# Altium schematic sheet [04] - USBtoUART.SchDoc
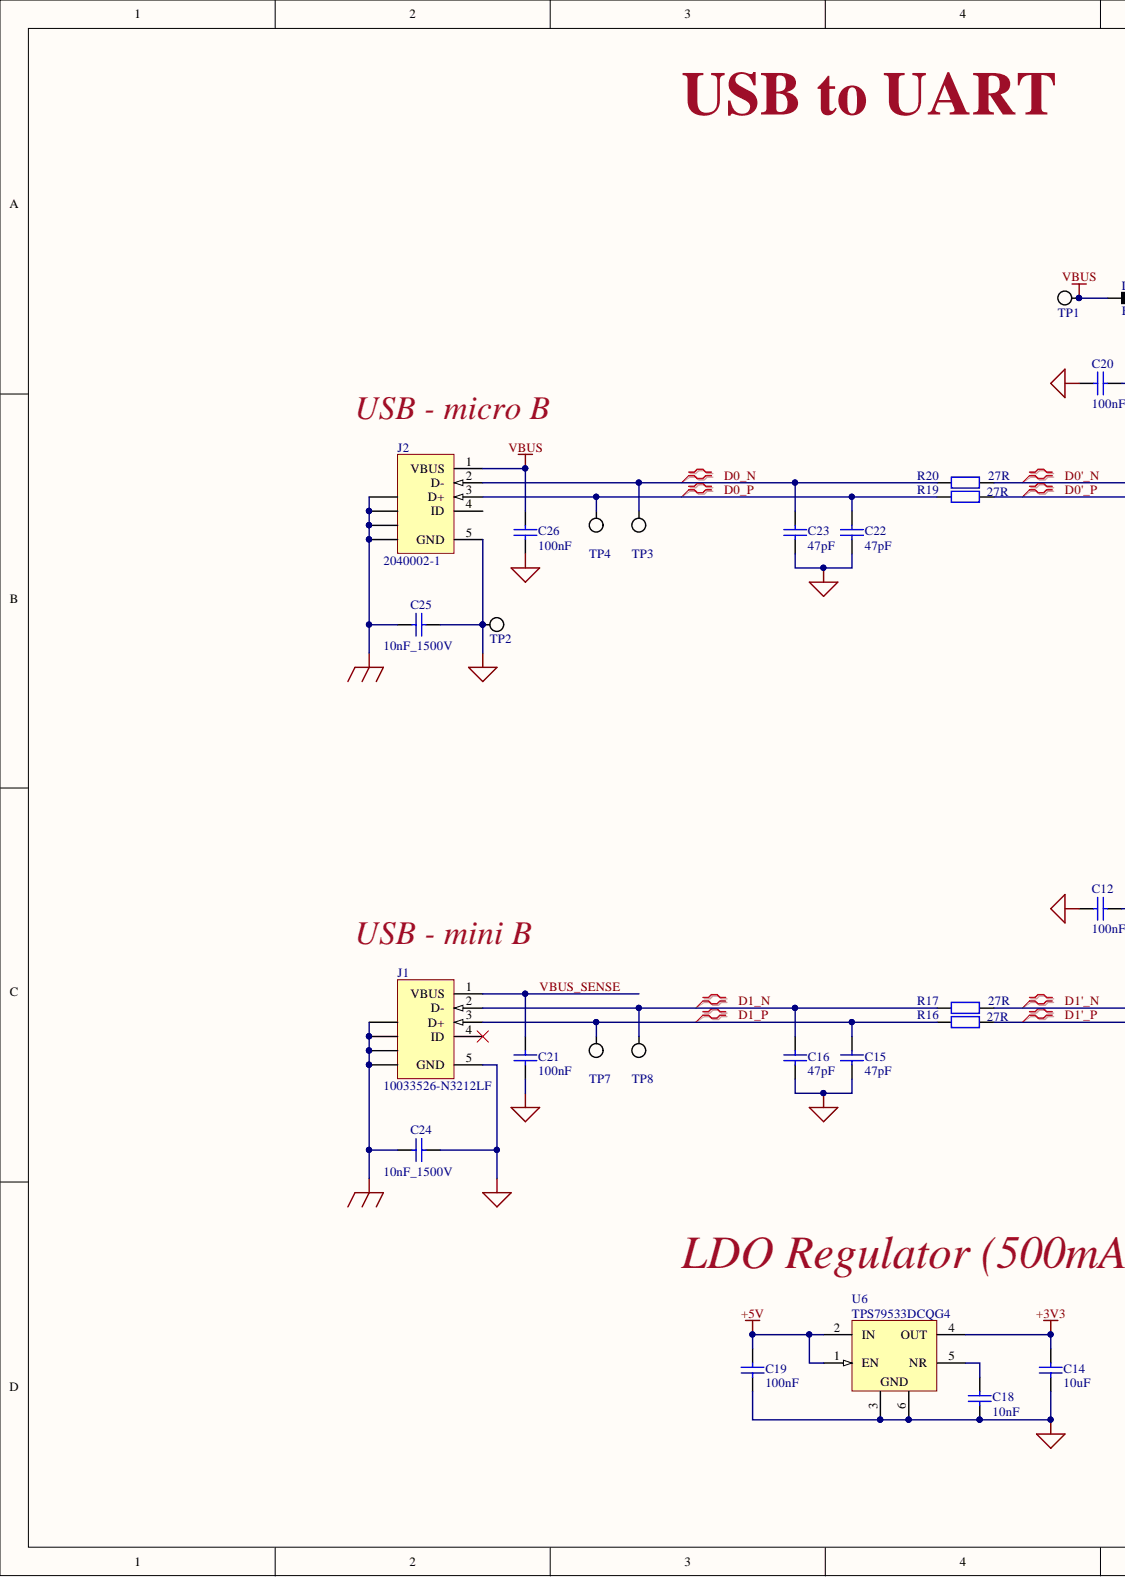
[diagram p0: [04] - USBtoUART.SchDoc, left]
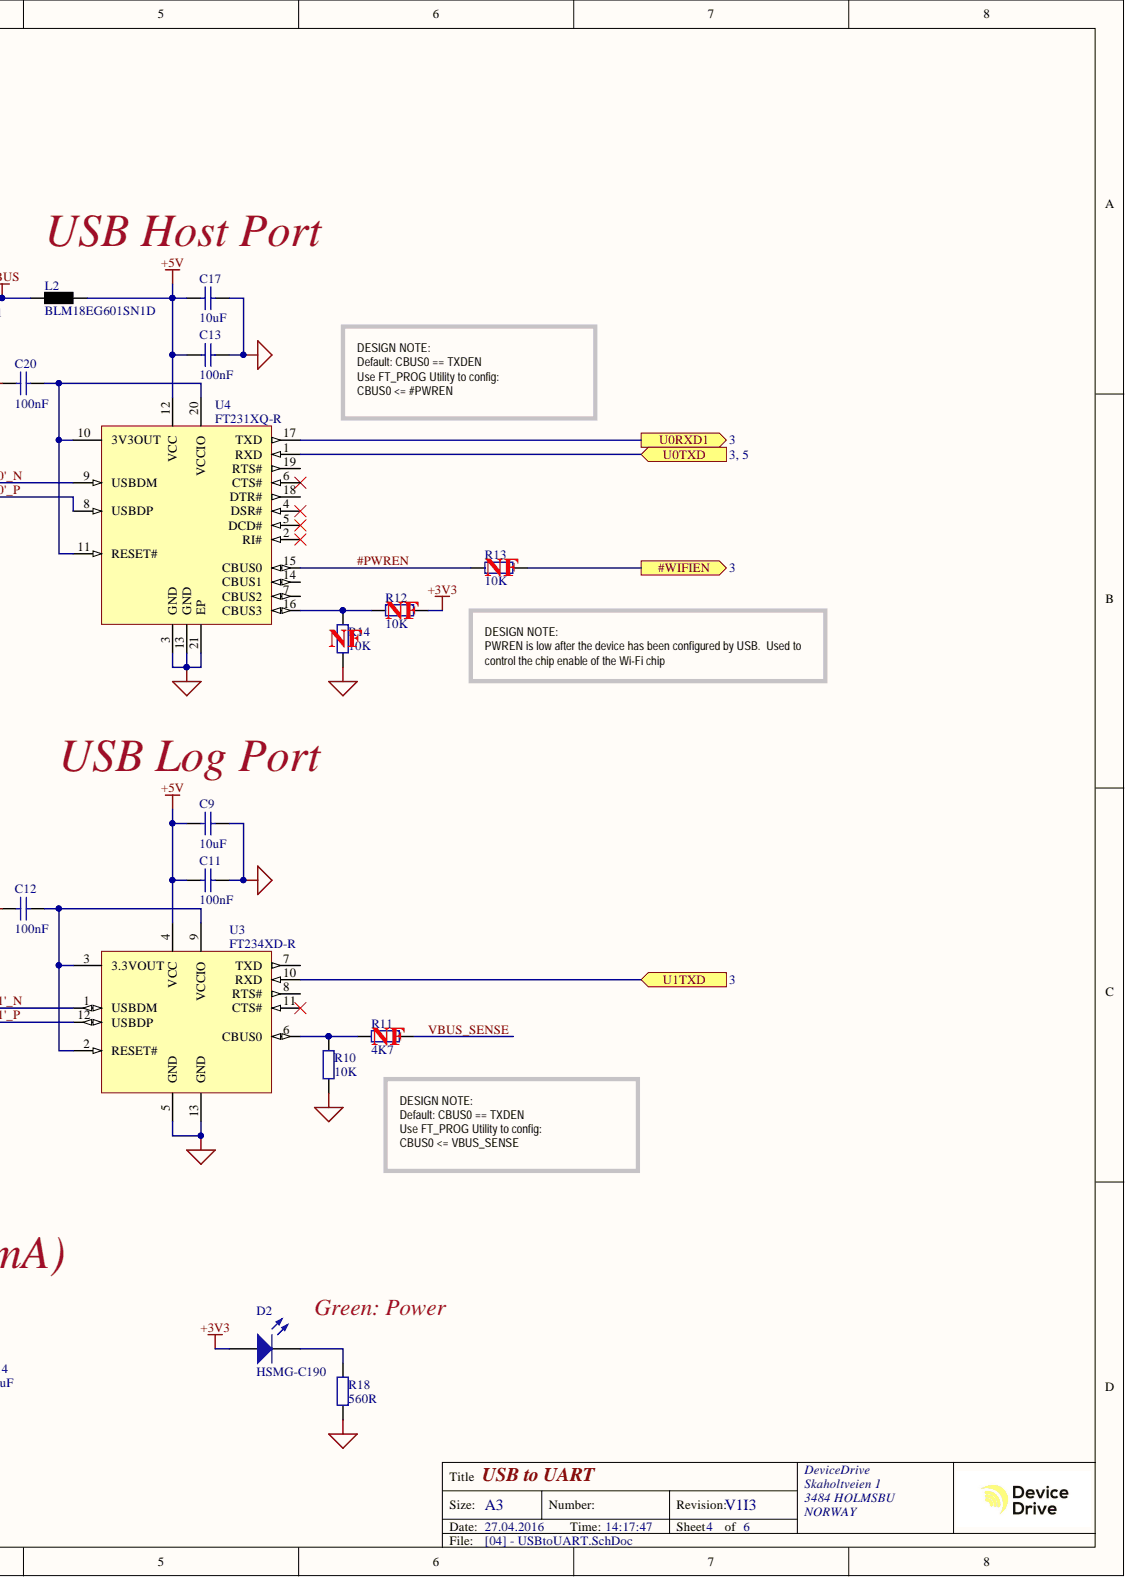
[diagram p1: [04] - USBtoUART.SchDoc, right]

## Parts
C9  10uF  [C0603]
C11  100nF  [C0402]
C12  100nF  [C0402]
C13  100nF  [C0402]
C14  10uF  [C0603]
C15  47pF  [C0402]
C16  47pF  [C0402]
C17  10uF  [C0603]
C18  10nF  [C0402]
C19  100nF  [C0402]
C20  100nF  [C0402]
C21  100nF  [C0402]
C22  47pF  [C0402]
C23  47pF  [C0402]
C24  10nF_1500V  [C1206]
C25  10nF_1500V  [C1206]
C26  100nF  [C0402]
D2  HSMG-C190  [LED_0603_GREEN]
J1  10033526-N3212LF  Connector Type: USB - mini B  [440479]
J2  2040002-1  [MICRO_USB_1]
L2  BLM18EG601SN1D  [R0603]
R10  10K  [R0402]
R11  4K7  [R0402]
R12  10K  [R0402]
R13  10K  [R0402]
R14  10K  [R0402]
R16  27R  [R0402]
R17  27R  [R0402]
R18  560R  [R0402]
R19  27R  [R0402]
R20  27R  [R0402]
TP1  Testpoint_1.5mm  [TESTPOINT_1.5MM]  (value not drawn)  (no BOM)
TP2  Testpoint_1.5mm  [TESTPOINT_1.5MM]  (value not drawn)  (no BOM)
TP3  Testpoint_1.5mm  [TESTPOINT_1.5MM]  (value not drawn)  (no BOM)
TP4  Testpoint_1.5mm  [TESTPOINT_1.5MM]  (value not drawn)  (no BOM)
TP7  Testpoint_1.5mm  [TESTPOINT_1.5MM]  (value not drawn)  (no BOM)
TP8  Testpoint_1.5mm  [TESTPOINT_1.5MM]  (value not drawn)  (no BOM)
U3  FT234XD-R  [DFN-12_V]
U4  FT231XQ-R  [QFN-20_N]
U6  TPS79533DCQG4  [TI-DCQ6_N]

## Ports
#WIFIEN, U0RXD1, U0TXD, U1TXD

## Nets
+3V3: C14.2 D2.2 R12.1 U6.4(OUT)
+5V: C9.2 C11.2 C13.2 C17.2 C19.2 L2.2 U3.4(VCC) U4.12(VCC) U6.1(EN) U6.2(IN)
EGND: C24.2 C25.2 J1.6 J1.7 J1.8 J1.9 J2.6 J2.7 J2.8 J2.9
GND: C9.1 C11.1 C12.2 C13.1 C14.1 C15.1 C16.1 C17.1 C18.1 C19.1 C20.2 C21.1 C22.1 C23.1 C24.1 C25.1 C26.1 J1.5(GND) J2.5(GND) R10.1 R14.2 R18.1 TP2.1 U3.5(GND) U3.13(GND) U4.3(GND) U4.13(GND) U4.21(EP) U6.3 U6.6
VBUS: C26.2 J2.1(VBUS) L2.1 TP1.1
#PWREN: R13.2 U4.15(CBUS0)
#WIFIEN: R13.1 ; port #WIFIEN
D0'_N: R20.2 U4.9(USBDM)
D0'_P: R19.2 U4.8(USBDP)
D0_N: C23.2 J2.2(D-) R20.1 TP3.1
D0_P: C22.2 J2.3(D+) R19.1 TP4.1
D1'_N: R17.2 U3.1(USBDM)
D1'_P: R16.2 U3.12(USBDP)
D1_N: C16.2 J1.2(D-) R17.1 TP8.1
D1_P: C15.2 J1.3(D+) R16.1 TP7.1
U0RXD1: U4.17(TXD) ; port U0RXD1
U0TXD: U4.1(RXD) ; port U0TXD
U1TXD: U3.10(RXD) ; port U1TXD
VBUS_SENSE: C21.2 J1.1(VBUS) R11.1
NetC12_1: C12.1 U3.2(RESET#) U3.3(3.3VOUT) U3.9(VCCIO)
NetC18_2: C18.2 U6.5(NR)
NetC20_1: C20.1 U4.10(3V3OUT) U4.11(RESET#) U4.20(VCCIO)
NetD2_1: D2.1 R18.2
NetR10_2: R10.2 R11.2 U3.6(CBUS0)
NetR12_2: R12.2 R14.1 U4.16(CBUS3)

Not connected: J1 4(ID); J2 4(ID); U3 7(TXD) 8(RTS#) 11(CTS#); U4 2(RI#) 4(DSR#) 5(DCD#) 6(CTS#) 7(CBUS2) 14(CBUS1) 18(DTR#) 19(RTS#)

## Library data of the parts
C9 C11-C13 C15-C17 C20-C26 = Cap: Capacitor; Value: 100pF; Component Kind: Standard; LatestRevisionDate: 17-Jul-2002; LatestRevisionNote: Re-released for DXP Platform.; Library Name: Miscellaneous Devices.IntLib; PackageDescription: Radial Cap, Thru-Hole; 2 Leads; 0.3 in Pin Spacing; PackageReference: RAD-0.3; Published: 8-Jun-2000; Signal Integrity: Cap; Simulation: CAP; Publisher: Altium Limited
C14 C18 C19 = Cap Semi: Capacitor (Semiconductor SIM Model); Value: 1nF; Component Kind: Standard; LatestRevisionDate: 17-Jul-2002; LatestRevisionNote: Re-released for DXP Platform.; PackageReference: C1206; Published: 8-Jun-2000; Signal Integrity: Cap Semi; Simulation: CAP; Library Name: PPSPowerManagement.SCHLIB; Publisher: Altium Limited
D2 = HSMG-C190: Surface Mount Chip LED, Green; LuminousIntensity: 4mcd (Minimum).; PCB_Layout: Complies with manufacturer's recommendation.; Component Kind: Standard; ComponentLink1Description: Manufacturer Link; ComponentLink1URL: http://www.agilent.com/; ComponentLink2Description: Datasheet; ComponentLink2URL: http://cp.literature.agilent.com/litweb/pdf/5988-6271EN.pdf; DatasheetDocument: 30-Apr-2002; LED_Colour: Green; Library Name: Agilent Optoelectronic LED.IntLib; PackageDocument: 11-Mar-2002; PackageReference: 1.6X0.8X0.8; Published: 11-Dec-2002; Signal Integrity: LED; Simulation: Agl_HSMGC110; Publisher: Altium Limited
J1 = 440479-1: CONN MINI USB RCPT RA TYPE B SMD; Manufacturer: FCI; Gender: Receptacle; Number of Contacts: 5; Category: Connectors, Interconnects; Component Kind: Standard; ComponentLink1Description: http://portal.fciconnect.com/Comergent//fci/drawing/10033526.pdf; ComponentLink1URL: http://portal.fciconnect.com/Comergent//fci/drawing/10033526.pdf; Features: Board Guide, Solder Retention; Ingress Protection: -; Library Name: AMP Serial Bus USB.IntLib; Manufacturer Part Number: 10033526-N3212LF; Mounting Type: Surface Mount, Right Angle, Horizontal; Packaging: Tape & Reel (TR); Pricing 1: 750=0.31704, 1500=0.28181, 3750=0.26419, 5250=0.25539, 18750=0.23425, 37500=0.22897 (EUR); RoHS: RoHS Compliant; Signal Integrity: USB2.0; Specifications: -; Stock 1: 14250; Supplier 1: Digi-Key; Supplier Part Number 1: 609-4700-2-ND
J2 = 440479-1: CONN PCB MICRO USB 5POS; Manufacturer: TE Connectivity AMP Connectors; Connector Type: USB - micro B; Gender: Receptacle; Number of Contacts: 5; Category: Connectors, Interconnects; Component Kind: Standard; ComponentLink1Description: http://www.te.com/commerce/DocumentDelivery/DDEController?Action=srchrtrv&DocNm=2040002&DocType=Customer+Drawing&DocLang=English; ComponentLink1URL: http://www.te.com/commerce/DocumentDelivery/DDEController?Action=srchrtrv&DocNm=2040002&DocType=Customer+Drawing&DocLang=English; Features: Board Guide, Solder Retention; Ingress Protection: -; Library Name: AMP Serial Bus USB.IntLib; Manufacturer Part Number: 2040002-1; Mounting Type: Surface Mount, Right Angle, Horizontal; Packaging: Digi-Reel®; Pricing 1: 1=2.25 (EUR); RoHS: RoHS Compliant; Signal Integrity: USB2.0; Specifications: -; Stock 1: 5939; Supplier 1: Digi-Key; Supplier Part Number 1: A108263DKR-ND
L2 = FerriteBead: MURATA - BLM18EG601SN1D - FERRITE BEAD, 0.35OHM, 500MA, 0603; DC Current Rating: 500; DC Resistance Max: 0.35; Ferrite Case Style: 0603 [1608 Metric]; Ferrite Mounting: SMD; Impedance @ 100MHz: 600; Impedance Tolerance: ± 25%; Product Range: BLM18; Resistance: 0.35ohm<!--FANDB--><div class="features-and-benefits-container"><div class="features-and-benefits-description"><span class="features-benefits-value">The Murata BLM18EG series are EMIFIL chip ferrite beads for suppressing high frequency noise in GHz band general signal line applications. These chip ferrite beads are designed for reducing the possibility of resonance to provide undistorted signal waveform. EMIFIL chip ferrite beads do not require connection to ground so they are effectively used in circuits without stable ground lines. The nickel barrier structure of the external electrodes provides excellent solder heat resistance. BLM18EG series has modified internal electrode structure that minimizes stray capacitance and increases the effective frequency range.</span></div><div class="features-and-benefits-list"><ul class="features-and-benefits-list-list"><li class="features-and-benefits-list-item">EIA 0603 standard chip size</li><li class="features-and-benefits-list-item">Miniaturized ferrite beads</li><li class="features-and-benefits-list-item">Effective at low impedance line</li><li class="features-and-benefits-list-item">Available in selective impedance range values at 100MHz</li><li class="features-and-benefits-list-item">Low DC resistance</li><li class="features-and-benefits-list-item">Large rated current</li><li class="features-and-benefits-list-item">Excellent direct current characteristics</li><li class="features-and-benefits-list-item">Suitable for small and low profile equipments</li><li class="features-and-benefits-list-item">Operating temperature range from -55°C to 125; SVHC: No SVHC (17-Dec-2014); Component Kind: Standard; ComponentLink1Description: http://www.farnell.com/datasheets/5169.pdf; ComponentLink1URL: http://www.farnell.com/datasheets/5169.pdf; Core Material: Ferrite; Library Name: IoTProject_1.SCHLIB; Manufacturer: MURATA; Manufacturer Part Number: BLM18EG601SN1D; Operating Temperature Max: +125; Operating Temperature Min: -55; Packaging: Cut Tape; Pricing 1: 5=1,85, 50=1,25, 100=0,911, 250=0,728, 500=0,607 (EUR); RoHS: YES; Signal Integrity: Res; Simulation: RESISTOR; Stock 1: 4811; Supplier 1: Farnell; Supplier Part Number 1: 1515723
R10-R14 R16-R20 = Res2: Resistor; Value: 1K; Component Kind: Standard; LatestRevisionDate: 17-Jul-2002; LatestRevisionNote: Re-released for DXP Platform.; Library Name: Miscellaneous Devices.IntLib; PackageDescription: Axial Device, Thru-Hole; 2 Leads; 0.4 in Pin Spacing; PackageReference: AXIAL-0.4; Published: 8-Jun-2000; Signal Integrity: Res; Simulation: RESISTOR; Publisher: Altium Limited
TP1-TP4 TP7 TP8 = Testpoint_1.5mm: Testpoint 1mm; Library Name: PI_Elmek.SCHLIB
U3 = FT234XD-R: FT234XD USB to BASIC UART IC, -40 to +85 degC, 12-Pin DFN, Pb-Free, Tape and Reel; Manufacturer: FTDI; Component Kind: Standard; ComponentLink1Description: Manufacturer URL; ComponentLink1URL: http://www.ftdichip.com/; ComponentLink2Description: Datasheet; ComponentLink2URL: http://www.ftdichip.com/Support/Documents/DataSheets/ICs/DS_FT234XD.pdf; DatasheetVersion: ver 1.1, Feb-2013; Library Name: FTDI FT-X Series.IntLib; PackageDescription: 12-Pin Dual Flat No-Lead, 3 x 3 mm Body, 0.45 mm Pitch, Exposed Pad; PackageReference: DFN-12; PackageVersion: Feb-13; PartNumber: FT234XD-R; Supplier 1: Digi-Key; Supplier Part Number 1: 768-1178-1-ND
U4 = FT231XQ-R: USB to Full-Handshake UART Interface Chip, UHCI/OHCI/EHCI Compatible, USB 2.0 Compatible, -40 to +85 degC, 20-Pin QFN, Pb-Free, Tape and Reel; Manufacturer: FTDI; Code_JEDEC: MO-220WGGD-1; Component Kind: Standard; ComponentLink1Description: Manufacturer URL; ComponentLink1URL: http://www.ftdichip.com/; ComponentLink2Description: Datasheet; ComponentLink2URL: http://www.ftdichip.com/Support/Documents/DataSheets/ICs/DS_FT231X.pdf; ComponentLink3Description: FT231X Product Page; ComponentLink3URL: www.ftdichip.com/Products/ICs/FT231X.html; DatasheetVersion: ver 1.2, Feb-2013; Library Name: FTDI FT-X Series.IntLib; PackageDescription: 20-Pin Quad Flat No-Lead, 4 x 4 mm Body, 0.5 mm Pitch; PackageReference: QFN-20; PackageVersion: Feb-13; PartNumber: FT231XQ-R; Supplier 1: Digi-Key; Supplier Part Number 1: 768-1155-ND
U6 = TPS79533DCQ: Single Output High PSRR LDO, 500 mA, Fixed 3.3 V Output, 2.7 to 5.5 V Input, 6-pin SOT-223 (DCQ), Green (RoHS & no Sb/Br); Manufacturer: Texas Instruments; Current - Limit (Min): 2.4A; Current - Output: 500mA; Number of Regulators: 1; Regulator Topology: Positive Fixed; Voltage - Dropout (Typical): 0.105V @ 500mA; Voltage - Input: Up to 5.5V; Voltage - Output: 3.3V; Category: Integrated Circuits (ICs); Component Kind: Standard; ComponentLink1Description: http://www.ti.com/lit/ds/symlink/tps79501.pdf; ComponentLink1URL: http://www.ti.com/lit/ds/symlink/tps79501.pdf; Library Name: TI Power Management Linear Regulator Single Channel LDO (400-7500mA).IntLib; Manufacturer Part Number: TPS79533DCQG4; Mounting Type: Surface Mount; Operating Temperature: -40°C ~ 125°C; Package / Case: SOT-223-6; Packaging: Tube; Pricing 1: 1=2.64, 10=2.362, 50=2.1262, 100=1.9372, 250=1.74816, 500=1.56862, 750=1.41744, 1000=1.32294 (EUR); RoHS: RoHS Compliant; Stock 1: 287; Supplier 1: Digi-Key; Supplier Device Package: SOT-223-6; Supplier Part Number 1: 296-41943-5-ND
